# Altium schematic sheet HolidayTree_Schematic_Logic.SchDoc(HolidayTree_Schematic_Logic)
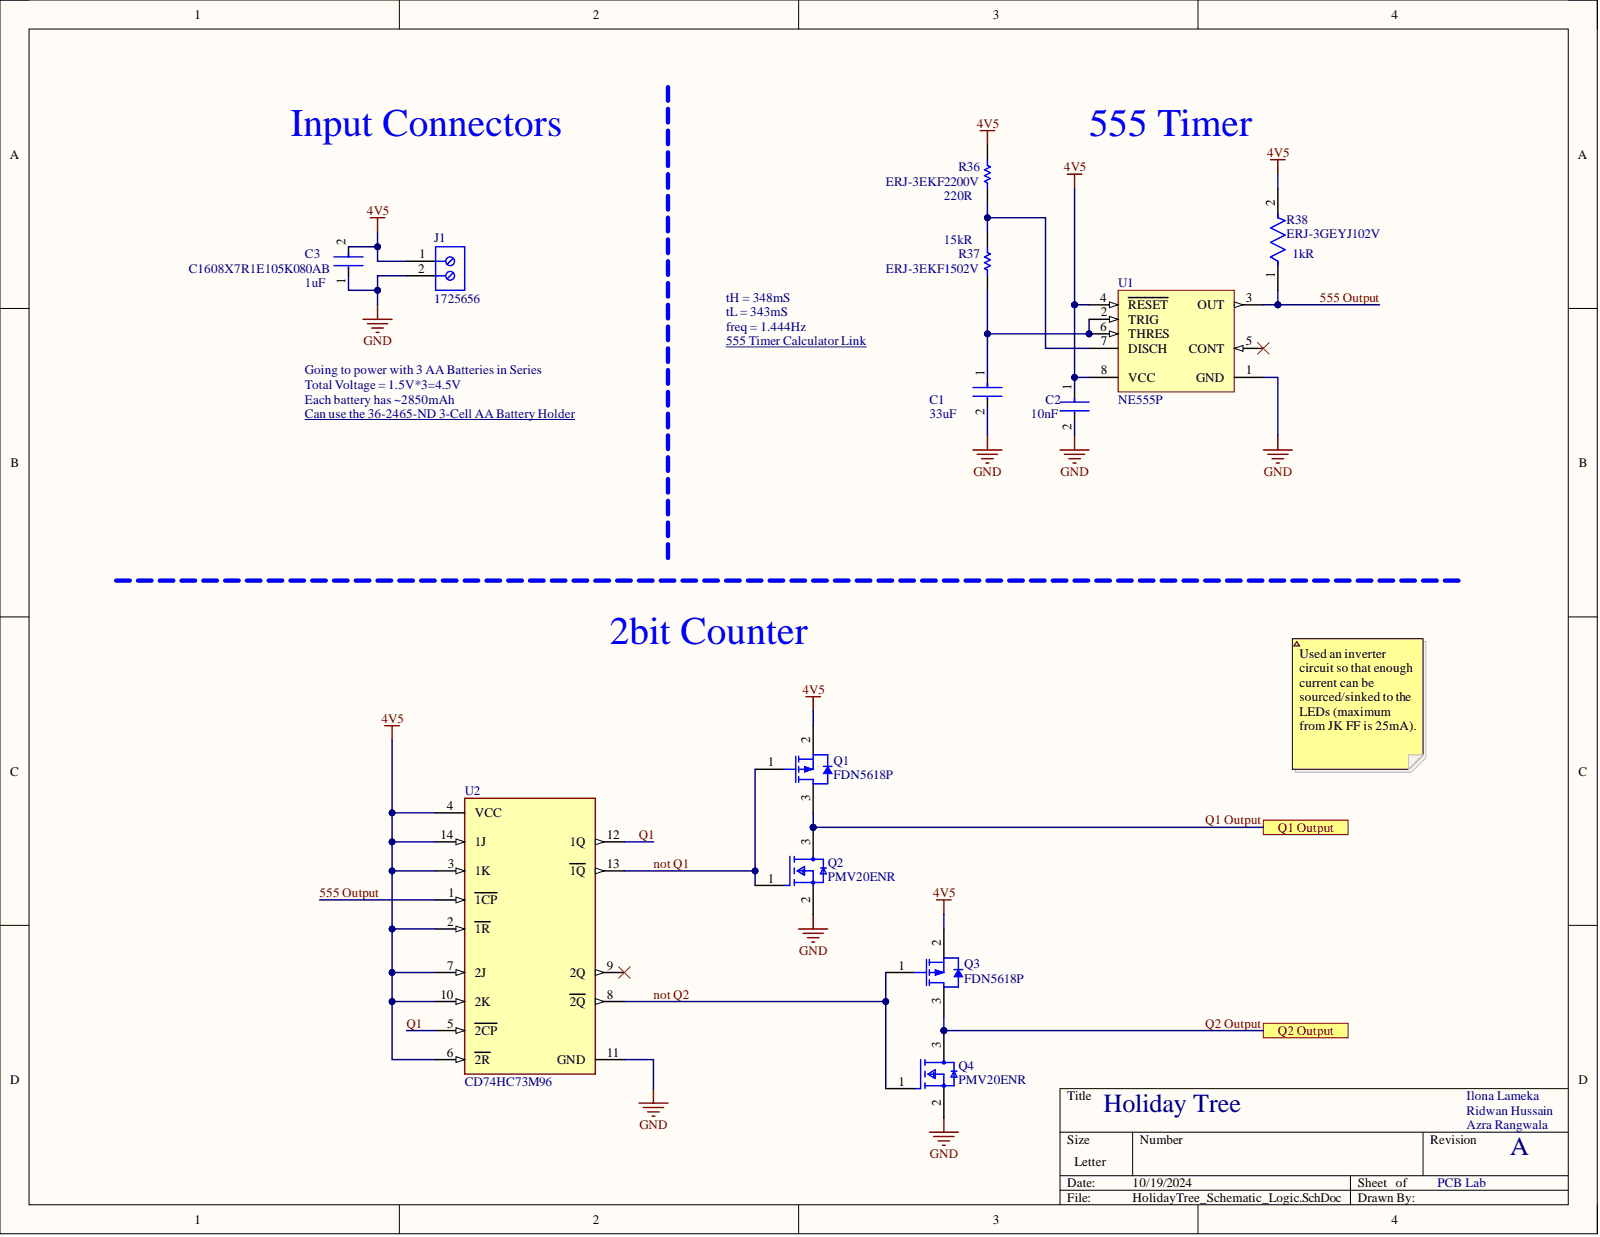
[diagram p0: HolidayTree_Schematic_Logic.SchDoc]

## Parts
C1  Capacitance: 33uF  (hidden comment: C2012X6S0G336M125AC)
C2  Capacitance: 10nF  (hidden comment: CL10B103MB8NNNC)
C3  C1608X7R1E105K080AB  Capacitance: 1uF
J1  1725656
Q1  FDN5618P
Q2  PMV20ENR
Q3  FDN5618P
Q4  PMV20ENR
R36  ERJ-3EKF2200V
R37  ERJ-3EKF1502V
R38  ERJ-3GEYJ102V
U1  NE555P
U2  CD74HC73M96

## Ports
Q1 Output, Q2 Output

## Nets
4V5: C2.1 C3.2 J1.1 Q1.2 Q3.2 R36.2 R38.2 U1.4(RESET) U1.8(VCC) U2.2(1R) U2.3(1K) U2.4(VCC) U2.6(2R) U2.7(2J) U2.10(2K) U2.14(1J)
GND: C1.2 C2.2 C3.1 J1.2 Q2.2 Q4.2 U1.1(GND) U2.11(GND)
555 Output: R38.1 U1.3(OUT) U2.1(1CP)
not Q1: Q1.1 Q2.1 U2.13(1Q)
not Q2: Q3.1 Q4.1 U2.8(2Q)
Q1: U2.5(2CP) U2.12(1Q)
Q1 Output: Q1.3 Q2.3 ; port Q1 Output
Q2 Output: Q3.3 Q4.3 ; port Q2 Output
NetC1_1: C1.1 R37.1 U1.2(TRIG) U1.6(THRES)
NetR36_1: R36.1 R37.2 U1.7(DISCH)

Not connected: U1 5(CONT); U2 9(2Q)

## Library data of the parts
C1 = CMP-08246-005951-1: Multilayer Ceramic Capacitors 33μF ±20% 4V X6S SMD 0805; Case Code (Imperial): 0805; Case Code (Metric): 2012; Case/Package: 0805; Component Kind: Standard; Dielectric: X6S; Dielectric Material: Ceramic; Height: 1.25mm; Lead Free: Lead Free; Length: 0.079inch; Library Name: Altium Content Vault; Max Operating Temperature: 105°C; Min Operating Temperature: -55°C; Mount: Surface Mount; Package Quantity: 2000; Packaging: Tape and Reel; Resistor Type: Ceramic; RoHS Compliant: Yes; Series: C; Termination: SMD/SMT; Thickness: 0.057inch; Tolerance: 20%; Voltage Rating: 4V; Voltage Rating (DC): 4V; Width: 0.049inch
C2 = CMP-13271-000193-1: Cap Ceramic 10nF 50V X7R ±20% Pad SMD 0603 +125°C T/R; Case Code (Imperial): 0603; Case Code (Metric): 1608; Case/Package: 0603; Component Kind: Standard; Depth: 0.8mm; Dielectric: X7R; Height: 0.8mm; Lead Free: Lead Free; Length: 1.6mm; Library Name: Altium Content Vault; Max Operating Temperature: 125°C; Min Operating Temperature: -55°C; Mount: Surface Mount; Package Quantity: 4000; Packaging: Tape and Reel; Radiation Hardening: No; Thickness: 0.035inch; Tolerance: 20%; Voltage Rating: 50V; Voltage Rating (DC): 50V; Width: 0.031inch
C3 = CMP-08246-005723-1: Multilayer Ceramic Capacitors 1μF ±10% 25V X7R SMD 0603; Case Code (Imperial): 0603; Case Code (Metric): 1608; Case/Package: 0603; Component Kind: Standard; Construction: Flat; Depth: 0.8mm; Dielectric: X7R; Dielectric Material: Ceramic; Height: 0.8mm; Lead Free: Lead Free; Length: 1.6mm; Library Name: Altium Content Vault; Max Operating Temperature: 125°C; Min Operating Temperature: -55°C; Mount: Surface Mount; Package Quantity: 4000; Packaging: Tape and Reel; Radiation Hardening: No; REACH SVHC: No SVHC; Resistor Type: Ceramic; RoHS Compliant: Yes; Series: C; Termination: SMD/SMT; Thickness: 0.037inch; Tolerance: 10%; Voltage: 25V; Voltage Rating: 25V; Voltage Rating (DC): 25V; Weight: 7.1E-05oz; Width: 0.031inch
J1 = CMP-2000-05299-1: Male Header, Pitch 2.54 mm, 1 x 2 Position, Height 9 mm, Tail Length 3.5 mm; Manufacturer: Phoenix Contact; Content: 250pc(s); Industrial packaging: Yes; Number of contacts: 2; Pitch: 2.54mm; Colour: Green; Component Kind: Standard; Datasheet URL: http://datasheet.octopart.com/1725656-Phoenix-Contact-datasheet-5302866.pdf; Datasheet Version: Apr-08; Library Name: Altium Content Vault; Manufacturer part No.: 1725656; Manufacturer URL: http://www.phoenixcontact.com/; Type: MPT0,5/2-2,54
Q1 Q3 = CMP-2000-06255-1: P-Channel Logic Level PowerTrench(R) MOSFET, -60 V, -1.25 A, 3-Pin SOT23, RoHS, Tape and Reel; Manufacturer: Fairchild Semiconductor; Continuous Drain Current Id: 1.25A; Current Id Max: 1.2A; Current Temperature: 25°C; Device Marking: 618; Drain Source Voltage Vds: 60V; External Depth: 2.5mm; External Length / Height: 1.12mm; External Width: 3.05mm; No. of Pins: 3; On Resistance Rds(on): 170mohm; Package Version: Rev. 3, 05/2015; Power Dissipation Pd: 500mW; Pulse Current Idm: 10A; Rds(on) Test Voltage Vgs: -10V; SVHC: NoSVHC(19-Dec-2012); Threshold Voltage Vgs Typ: 20V; Transistor Case Style: SOT-23; Transistor Polarity: PChannel; Voltage Vds Typ: -60V; Voltage Vgs th Max: -3V; Component Kind: Standard; Datasheet URL: https://www.fairchildsemi.com/datasheets/FD/FDN5618P.pdf; Datasheet Version: Rev. C, 10/2000; Full Power Rating Temperature: 25°C; Library Name: Altium Content Vault; Manufacturer URL: https://www.fairchildsemi.com/; Mounting Technology: SurfaceMount; MSL: –; Operating Temperature Max: 150°C; Operating Temperature Min: -55°C; Operating Temperature Range: -55°Cto+150°C; Package / Case: SOT-23; Package Description: 3-Pin Small Outline Transistor, Body 2.92 x 1.4 mm, Pitch 0.95 mm; Package Reference: SSOT3L; Package URL: https://www.fairchildsemi.com/package-drawings/MA/MA03B.pdf; SMD Marking: 618; Tape Width: 8mm; Voltage Vds: 60V; Voltage Vgs Max: 20V; Voltage Vgs Rds on Measurement: -10V
Q2 Q4 = CMP-14409-000013-1: MOSFET N-CH 30V SOT23; Component Kind: Standard; Library Name: Altium Content Vault
R36 = CMP-2000-00335-2: Chip Resistor, 220 Ohm, +/- 1%, 0.1 W, -55 to 155 degC, 0603 (1608 Metric), RoHS, Tape and Reel; Case\Package: 0603; Power Rating: 100 mW; Resistance: 22 Ohm; Voltage Rating: 50 V; Case Code (Imperial): 0603; Case Code (Metric): 1608; Component Kind: Standard; ComponentLink1Description: Manufacturer URL; ComponentLink1URL: http://www.panasonic.com/; ComponentLink2Description: Datasheet; ComponentLink2URL: http://industrial.panasonic.com/cdbs/www-data/pdf/RDA0000/AOA0000C86.pdf; DatasheetVersion: Apr-15; Height: 0.45 mm; Length: 1.6 mm; Library Name: Altium Content Vault; Max Operating Temperature: 155 degC; Min Operating Temperature: -55 degC; Mount: Surface Mount; Package Quantity: 5000; PackageDescription: 2-Pin Surface Mount Device, Body 1.6 x 0.85 mm; PackageReference: 0603; Packaging: Tape and Reel; Pins: 2; REACH SVHC: No SVHC; RoHSCompliant: true; Tolerance: 1%; Voltage Rating (DC): 50 V; Width: 0.8 mm
R37 = CMP-2000-00258-1: Manufacturer: Panasonic; Component Kind: Standard; Library Name: Altium Content Vault; Part Number: ERJ-3EKF1502V
R38 = CMP-2000-00703-2: RES SMD 1K OHM 5% 1/10W 0603; Manufacturer: Panasonic; Automotive Grade: Grade 0; Power Rating: 0.1W; Resistance: 1000Ω; Temperature Coefficient: 200ppm/°C; Value: 1000Ω; Voltage: 75 V; Voltage Rating: 75V; Automotive: Yes; Category: Res; Component Kind: Standard; ComponentLink2Description: Datasheet; ComponentLink2URL: https://industrial.panasonic.com/cdbs/www-data/pdf/RDA0000/AOA0000C301.pdf; Composition: Thick Film; Device Class L1: Passive Components; Device Class L2: Resistors; Device Class L3: Chip SMD Resistors; Digikey Description: RES SMD 1K OHM 5% 1/10W 0603; Footprint Url: https://industrial.panasonic.com/cdbs/www-data/pdf/RDA0000/DMA0000COL17.pdf; Height: 0.55mm; Ipc Land Pattern Name: RESC16080X45; Lead Free: Yes; Library Name: Altium Content Vault; Manufacturer Part Number: ERJ-3GEYJ102V; Mounting Type: surface mount; Mouser Description: Res Thick Film 0603 1K Ohm 5% 1/10W ±200ppm/°C Molded SMD Punched Carrier T/R; Octopart: https://octopart.com/erj-3geyj102v-panasonic-55403670; Package: ERJ3G; RoHS: TRUE; Series: ERJ; Temperature: -55°C to +155°C; Temperature Range High: +155°C; Temperature Range Low: -55°C; Tolerance: 5%
U1 = CMP-2000-04954-1: Precision Timer, 4.5 to 16 V, 0 to 70 degC, 8-Pin DIP, Pb-Free, Tube; Manufacturer: TexasInstruments; Maximum High Level Output Current: -200mA; Maximum Low Level Output Current: 200mA; Number of Internal Timers: 1; Operating Supply Voltage: 5; Rad Hard: No; Category: Timer; Component Kind: Standard; Datasheet URL: http://www.ti.com/general/docs/lit/getliterature.tsp?genericPartNumber=NE555&fileType=pdf; Datasheet Version: Rev. I, 09/2014; Library Name: Altium Content Vault; Manufacturer URL: http://www.ti.com/; Package: 8PDIP; Package Description: 8-Pin Double In-line Package, Body 9.59 x 6.35 mm, Pitch 2.54 mm; Package Reference: P8; Package URL: http://www.ti.com/lit/ml/mpdi001b/mpdi001b.pdf; Packaging: Rail/Tube; Type: Standard
U2 = CMP-04902-000019-1: IC FF JK TYPE DUAL 1BIT 14SOIC; Clock Edge Trigger Type: Negative Edge; Frequency: 23MHz; High Level Output Current: -5.2mA; Input Type: SINGLE-ENDED; Logic Function: Flip-Flop; Low Level Output Current: 5.2mA; Max Supply Voltage: 6V; Min Supply Voltage: 2V; Number of Bits: 2; Number of Bits per Element: 1; Output Current: 5.2A; Polarity: Non-Inverting; Propagation Delay: 13ns; Case/Package: SOIC; Component Kind: Standard; Lead Free: Lead Free; Library Name: Altium Content Vault; Max Frequency: 23MHz; Max Operating Temperature: 125°C; Min Operating Temperature: -55°C; Mount: Surface Mount; Number of Circuits: 2; Number of Elements: 2; Number of Input Lines: 2; Number of Output Lines: 1; Number of Pins: 14; Package Quantity: 2500; Packaging: Tape and Reel; Radiation Hardening: No; REACH SVHC: No SVHC; RoHS Compliant: Yes; Series: 74HC; Technology: CMOS; Turn-On Delay Time: 13ns; Weight: 0.004564oz
